# Altium schematic sheet x-IMU3-SA-Sync.SchDoc
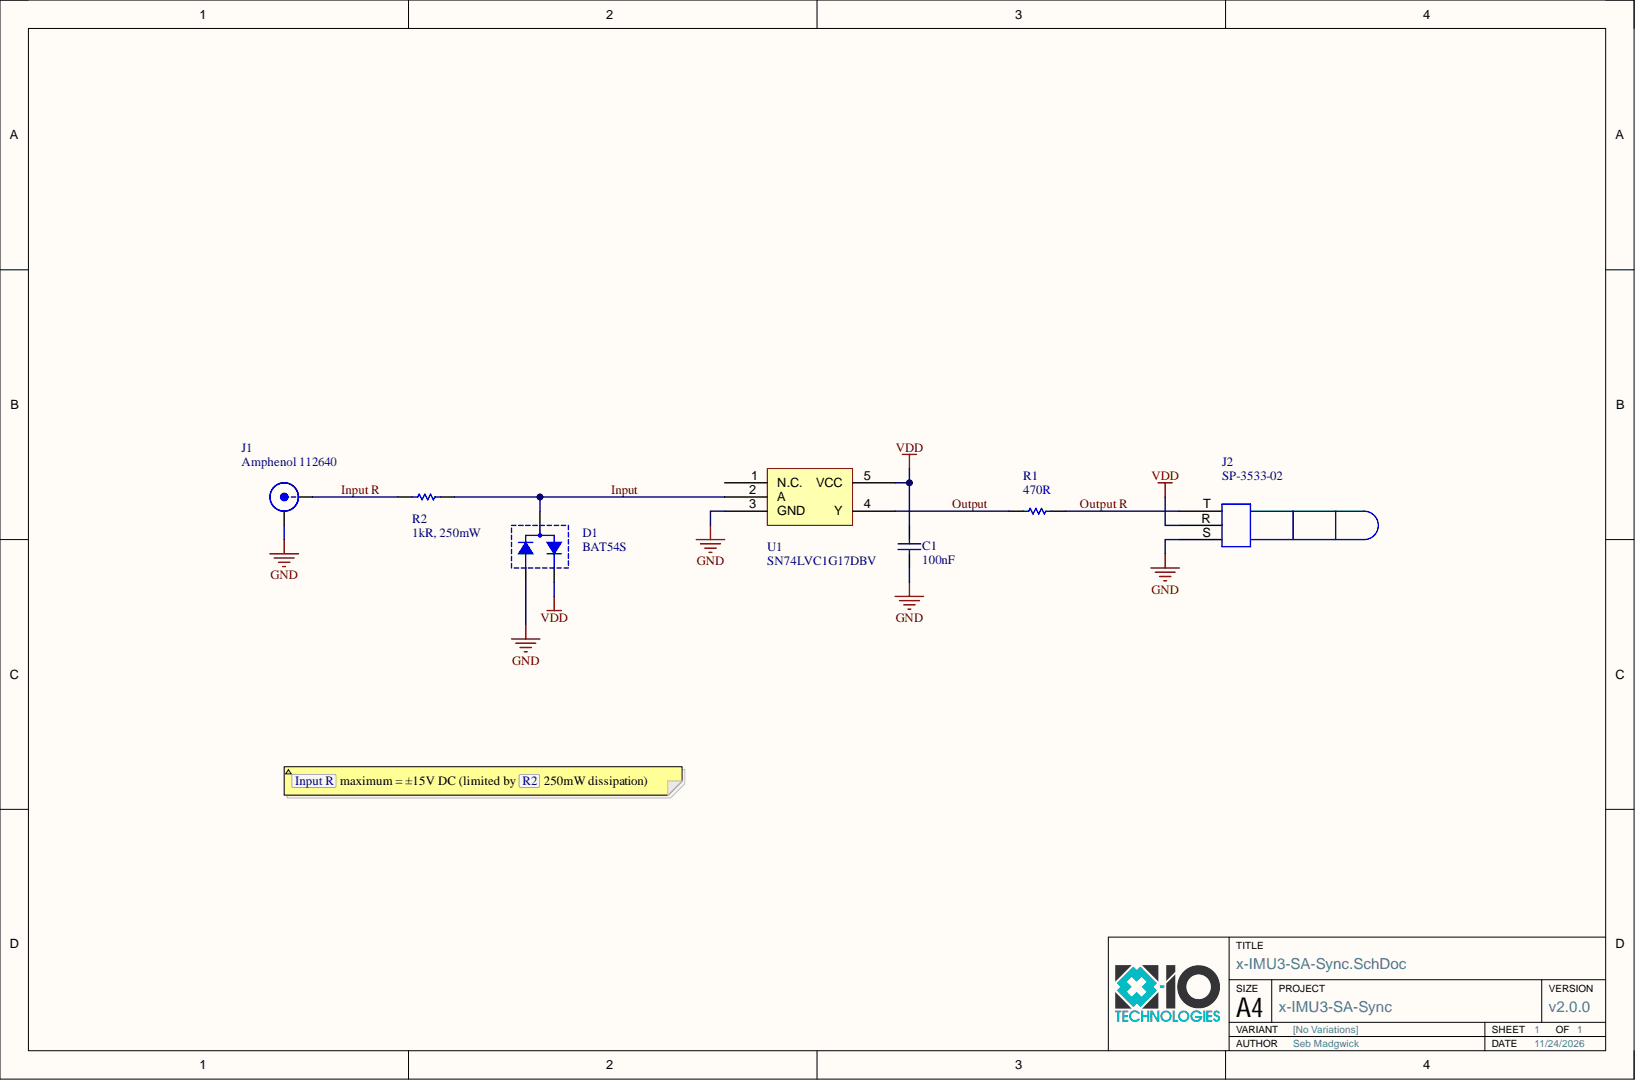
[diagram p0: x-IMU3-SA-Sync.SchDoc]

## Parts
C1  100nF  [Capacitor 0402]
D1  BAT54S  [SOT-23]
J1  Amphenol 112640  [Amphenol 112640]
J2  SP-3533-02  [SP-3533-02]
R1  470R  [Resistor 0402]
R2  1kR, 250mW  [Resistor 1206]
U1  SN74LVC1G17DBV  [SOT-23-5]

## Nets
GND: C1.1 D1.1 J1.Shield J2.S U1.3(GND)
VDD: C1.2 D1.2 J2.R U1.5(VCC)
Input: D1.3 R2.2 U1.2(A)
Input R: J1.Signal R2.1
Output: R1.1 U1.4(Y)
Output R: J2.T R1.2

Not connected: U1 1(N.C.)

## Library data of the parts
C1 = Capacitor: Component Kind: Standard; Library Name: x-io.SchLib
J1 = BNC: Component Kind: Standard; Library Name: x-io.SchLib
R1 R2 = Resistor: Component Kind: Standard; Library Name: x-io.SchLib
U1 = SN74LVC1xxx (1 Input): Component Kind: Standard; Library Name: x-io.SchLib
D1 = BAT54S: Component Kind: Standard; Library Name: x-io.SchLib
J2 = SP-3533-02: Component Kind: Standard; Library Name: x-io.SchLib
